# Altium schematic sheet FacePlateRev2b.SchDoc
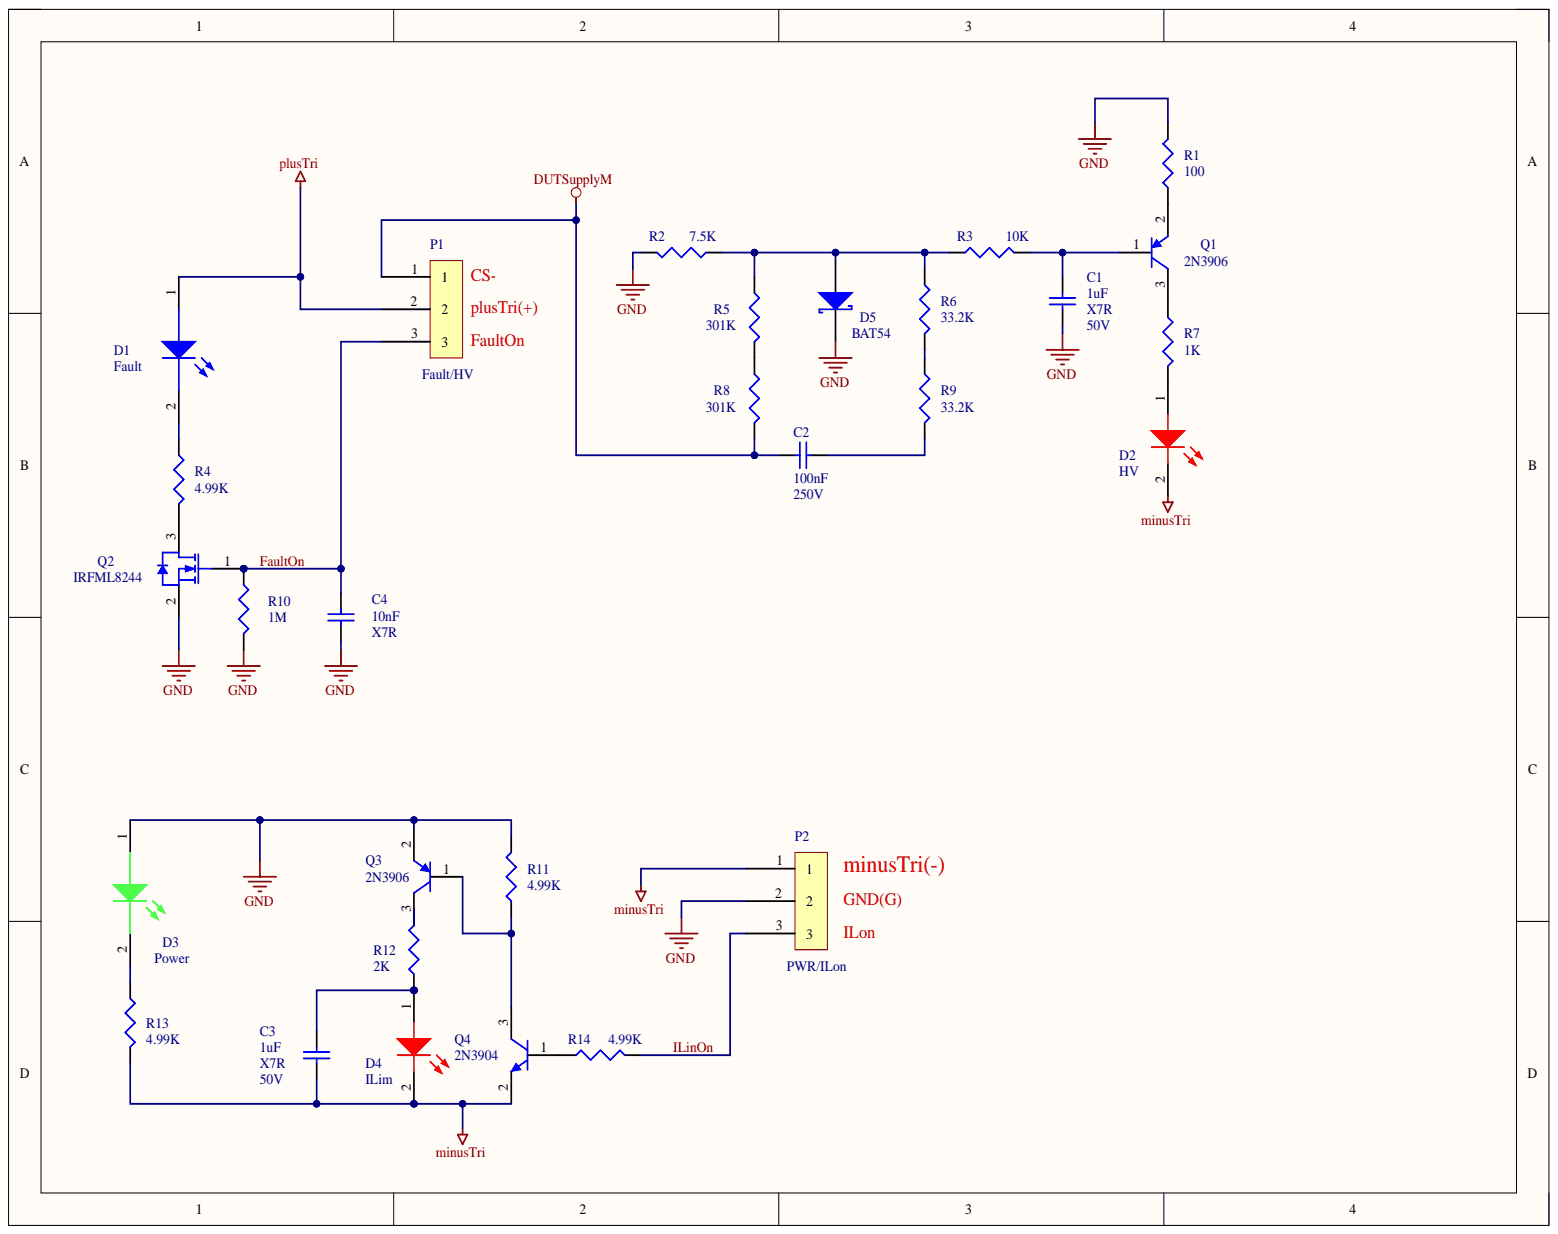
[diagram p0: FacePlateRev2b.SchDoc]

## Parts
C1  1uF  Dielectric: X7R  Voltage: 50V  [CAPC2012M]
C2  Capacitance: 100nF  Voltage Rating: 250V  [CAPC3216M]  (hidden comment: 0.1uF)
C3  1uF  Dielectric: X7R  Voltage: 50V  [CAPC2012M]
C4  10nF  Dielectric: X7R  [CAPC2012M]
D1  Fault  [LED-BOTTOM-ENTRY]
D2  HV  [LED-BOTTOM-ENTRY]
D3  Power  [LED-BOTTOM-ENTRY]
D4  ILim  [LED-BOTTOM-ENTRY]
D5  BAT54  [SOD123]
P1  Fault/HV  [NQ032]
P2  PWR/ILon  [NQ032]
Q1  2N3906  [SOT23-3M]
Q2  IRFML8244  [SOT23-3M]
Q3  2N3906  [SOT23-3M]
Q4  2N3904  [SOT23-3M]
R1  100  [RESC2012M]
R2  7.5K  [RESC2012M]
R3  10k  [RESC3216M]
R4  4.99K  [RESC3216M]
R5  value 301K  [RESC3216M]  (hidden comment: 226K)
R6  value 33.2K  [RESC3216M]  (hidden comment: 30.1K)
R7  1K  [RESC2012M]
R8  value 301K  [RESC3216M]  (hidden comment: 226K)
R9  value 33.2K  [RESC3216M]  (hidden comment: 30.1K)
R10  1M  [RESC2012M]
R11  4.99K  [RESC2012M]
R12  2K  [RESC2012M]
R13  4.99K  [RESC2012M]
R14  4.99K  [RESC3216M]

## Nets
DUTSupplyM: C2.2 P1.1 R8.2
GND: C1.2 C4.2 D3.1 D5.2 P2.2 Q2.2 Q3.2 R1.1 R2.1 R10.2 R11.2
minusTri: C3.2 D2.2 D4.2 P2.1 Q4.2 R13.2
plusTri: D1.1 P1.2
FaultOn: C4.1 P1.3 Q2.1 R10.1
ILinOn: P2.3 R14.2
NetC1_1: C1.1 Q1.1 R3.2
NetC2_1: C2.1 R9.2
NetC3_1: C3.1 D4.1 R12.1
NetD1_2: D1.2 R4.1
NetD2_1: D2.1 R7.2
NetD3_2: D3.2 R13.1
NetD5_1: D5.1 R2.2 R3.1 R5.1 R6.1
NetQ1_2: Q1.2 R1.2
NetQ1_3: Q1.3 R7.1
NetQ2_3: Q2.3 R4.2
NetQ3_1: Q3.1 Q4.3 R11.1
NetQ3_3: Q3.3 R12.2
NetQ4_1: Q4.1 R14.1
NetR5_2: R5.2 R8.1
NetR6_2: R6.2 R9.1

## Library data of the parts
C1 C3 = Cap: CAP CER 1UF 50V X7R 0805; Manufacturer: Samsung; Manufacturer Part Number: CL21B105KBFNNNE; Dielectric Material: Ceramic; Tolerance: 10%; Capacitance: 1uF; Case Code (Imperial): 0805; Case Code (Metric): 2012; Case/Package: 0805; Component Kind: Standard; Construction: Flat; Depth: 1.25mm; Height: 1.25mm; Length: 2mm; Library Name: Miscellaneous Devices.IntLib; Max Operating Temperature: 125°C; Min Operating Temperature: -55°C; Mount: Surface Mount; Number of Pins: 2; Package Quantity: 1; Packaging: Tape and Reel; Radiation Hardening: No; REACH SVHC: No SVHC; RoHS Compliant: Yes; Series: CL; Signal Integrity: Cap; Simulation: CAP; Supplier: Digi-Key; Supplier Part Number: 1276-1029-1-ND; Termination: SMD/SMT; Thickness: 0.053inch; Value: 1uF; Voltage Rating: 50V; Voltage Rating (DC): 50V; Width: 0.049inch
C2 = Cap: CAP CER 0.1UF 250V X7R 1206; Manufacturer: KEMET; Manufacturer Part Number: C1206C104KARECAUTO; Tolerance: 10%; Case Code (Imperial): 1206; Case Code (Metric): 3216; Case/Package: 1206; Component Kind: Standard; Library Name: Miscellaneous Devices.IntLib; Max Operating Temperature: 125°C; Min Operating Temperature: -55°C; Mount: Surface Mount; Packaging: Cut Tape; Ratings: AEC-Q200; Signal Integrity: Cap; Simulation: CAP; Supplier: Digi-Key; Supplier Part Number: 399-17175-1-ND; Value: 0.1uF
C4 = Cap: CAP CER 10NF 100V X7R 0805; Manufacturer: Samsung; Manufacturer Part Number: CL21B103KCANNNC; Dielectric Material: Ceramic; Dissipation Factor: 2.5%; Tolerance: 10%; Capacitance: 10nF; Case Code (Imperial): 0805; Case Code (Metric): 2012; Case/Package: 0805; Component Kind: Standard; Construction: Flat; Contact Plating: Tin; Depth: 1.25mm; Height: 0.65mm; Length: 2mm; Library Name: Miscellaneous Devices.IntLib; Material: Ceramic; Max Operating Temperature: 125°C; Min Operating Temperature: -55°C; Mount: Surface Mount; Number of Pins: 2; Package Quantity: 1; Packaging: Tape and Reel; Radiation Hardening: No; REACH SVHC: No SVHC; RoHS Compliant: Yes; Series: CL; Signal Integrity: Cap; Simulation: CAP; Supplier: Digi-Key; Supplier Part Number: 1276-1249-1-ND; Termination: SMD/SMT; Thickness: 0.03inch; Value: 10nF; Voltage: 100V; Voltage Rating: 100V; Voltage Rating (DC): 100V; Width: 0.049inch
D1 = Blue: BLUE LED 3.5X2.8MM SMD; Manufacturer: Kingbright; Manufacturer Part Number: AA3528QBS/D09; Value: LED BLUE; Category: LEDs; Component Kind: Standard; Library Name: My_LED.SchLib; Pricing 1: 1=0.55000, 10=0.35800, 100=0.23700, 1000=0.18300 (USD); RoHS: Yes; RoHS Compliant: Yes; Stock 1: 9964; Supplier: Digi-Key; Supplier Part Number: 754-AA3528QBS/D09CT-ND
D2 D4 = Red: RED LED 3.5X2.8MM SMD; Manufacturer: SunLED; Manufacturer Part Number: XZMDK45WT-9; ESR (Equivalent Series Resistance): 700mR; Lens Color: Colorless; Lens Size: 2.4mm; Lens Style: Round,Flat; Lens Transparency: Clear; Luminous Intensity: 98mcd; Value: LED RED; Category: LEDs; Color: Red; Component Kind: Standard; ComponentLink1Description: https://datasheet.ciiva.com/29561/150120rs75000-29561888.pdf?src-supplier=Digi-Key; ComponentLink1URL: https://datasheet.ciiva.com/29561/150120rs75000-29561888.pdf?src-supplier=Digi-Key; ComponentLink2Description: https://datasheet.ciiva.com/29279/759442-da-01-en-chipled-1206-w-kl-rot-150120rs75000-29279067.pdf?src-supplier=Conrad; ComponentLink2URL: https://datasheet.ciiva.com/29279/759442-da-01-en-chipled-1206-w-kl-rot-150120rs75000-29279067.pdf?src-supplier=Conrad; ComponentLink3Description: https://datasheet.ciiva.com/48430/150120rs75000-eng-tds-48430054.pdf?src-supplier=Distrelec; ComponentLink3URL: https://datasheet.ciiva.com/48430/150120rs75000-eng-tds-48430054.pdf?src-supplier=Distrelec; ComponentLink4Description: https://datasheet.ciiva.com/22656/1911465-22656982.pdf?src-supplier=Element14; ComponentLink4URL: https://datasheet.ciiva.com/22656/1911465-22656982.pdf?src-supplier=Element14; ComponentLink5Description: https://datasheet.ciiva.com/22657/156120rs75300-22657072.pdf?src-supplier=Element14; ComponentLink5URL: https://datasheet.ciiva.com/22657/156120rs75300-22657072.pdf?src-supplier=Element14; ComponentLink6Description: https://datasheet.ciiva.com/29279/759450-da-01-en-smd-chipled-rev-rot-156120rs75300-29279064.pdf?src-supplier=Conrad; ComponentLink6URL: https://datasheet.ciiva.com/29279/759450-da-01-en-smd-chipled-rev-rot-156120rs75300-29279064.pdf?src-supplier=Conrad; ComponentLink7Description: https://datasheet.ciiva.com/48430/156120rs75300-eng-tds-48430214.pdf?src-supplier=Distrelec; ComponentLink7URL: https://datasheet.ciiva.com/48430/156120rs75300-eng-tds-48430214.pdf?src-supplier=Distrelec; ComponentLink8Description: http://www.sunledusa.com/products/spec/XZMDK45WT-9.pdf?src-supplier=Digi-Key; ComponentLink8URL: http://www.sunledusa.com/products/spec/XZMDK45WT-9.pdf?src-supplier=Digi-Key; Library Name: My_LED.SchLib; Max Operating Temperature: 105°C; Min Operating Temperature: -55°C; Mount: Surface Mount; Packaging: Tape and Reel; Pricing 1: 1=0.57000, 10=0.37200, 100=0.24610, 1000=0.19004 (USD); Ratings: AEC-Q200; RoHS: Yes; RoHS Compliant: No; Stock 1: 137856; Supplier: Digi-Key; Supplier Part Number: 1497-1331-1-ND
D3 = Green: GREEN LED 3.5X2.8MM SMD; Manufacturer: SunLED; Manufacturer Part Number: XZVG45WT-9; Dominant Wavelength: 570nm; Forward Voltage: 2.1V; Lens Color: Colorless; Lens Size: 2.4mm; Lens Style: Round,Flat; Lens Transparency: Clear; Luminous Intensity: 98mcd; Test Current: 20mA; Value: LED GREEN; Viewing Angle: 120°; Case/Package: SMD/SMT; Category: LEDs; Color: Green; Component Kind: Standard; ComponentLink1Description: https://datasheet.ciiva.com/23843/156120vs75300-23843298.pdf?src-supplier=Newark; ComponentLink1URL: https://datasheet.ciiva.com/23843/156120vs75300-23843298.pdf?src-supplier=Newark; ComponentLink2Description: https://datasheet.ciiva.com/29279/759452-da-01-en-smd-chipled-rev-h-gruen-156120vs75300-29279069.pdf?src-supplier=Conrad; ComponentLink2URL: https://datasheet.ciiva.com/29279/759452-da-01-en-smd-chipled-rev-h-gruen-156120vs75300-29279069.pdf?src-supplier=Conrad; ComponentLink3Description: https://datasheet.ciiva.com/22657/1911474-22657077.pdf?src-supplier=Element14; ComponentLink3URL: https://datasheet.ciiva.com/22657/1911474-22657077.pdf?src-supplier=Element14; ComponentLink4Description: http://www.sunledusa.com/products/spec/XZMDK55W-3.pdf?src-supplier=Digi-Key; ComponentLink4URL: http://www.sunledusa.com/products/spec/XZMDK55W-3.pdf?src-supplier=Digi-Key; ComponentLink5Description: http://www.sunledusa.com/products/spec/XZVG45WT-9.pdf?src-supplier=Digi-Key; ComponentLink5URL: http://www.sunledusa.com/products/spec/XZVG45WT-9.pdf?src-supplier=Digi-Key; Height: 2.1mm; Length: 3.2mm; Library Name: My_LED.SchLib; Mount: Surface Mount; Packaging: Tape and Reel; Pricing 1: 1=0.52000, 10=0.34400, 100=0.22790, 1000=0.17596 (USD); RoHS: No; RoHS Compliant: No; Stock 1: 176753; Supplier: Digi-Key; Supplier Part Number: 1497-1336-1-ND; Width: 2.8mm
D5 = Diode-Schottkey-12: SBAT54T1G Series 30 200 mA Surface Mount Schottky Barrier Diode - SOD-123; Manufacturer: ON Semiconductor; Average Rectified Current: 200mA; Element Configuration: Single; Forward Voltage: 520mV; Max Forward Surge Current (Ifsm): 600mA; Max Power Dissipation: 400mW; Max Repetitive Reverse Voltage (Vrrm): 30V; Max Reverse Current: 500nA; Reverse Recovery Time: 5ns; Category: Schottky Diodes; Component Kind: Standard; ComponentLink1Description: http://www.farnell.com/datasheets/1840528.pdf?src-supplier=Farnell; ComponentLink1URL: http://www.farnell.com/datasheets/1840528.pdf?src-supplier=Farnell; ComponentLink2Description: http://www.mouser.com/ds/2/308/BAT54T1-D-108887.pdf?src-supplier=Mouser; ComponentLink2URL: http://www.mouser.com/ds/2/308/BAT54T1-D-108887.pdf?src-supplier=Mouser; ComponentLink3Description: http://www.onsemi.com/pub/Collateral/BAT54T1-D.PDF?src-supplier=Digi-Key; ComponentLink3URL: http://www.onsemi.com/pub/Collateral/BAT54T1-D.PDF?src-supplier=Digi-Key; ComponentLink4Description: http://www.onsemi.com/pub/Collateral/BAT54T1-D.PDF?src-supplier=Avnet; ComponentLink4URL: http://www.onsemi.com/pub/Collateral/BAT54T1-D.PDF?src-supplier=Avnet; Forward Current: 200mA; Lead Free: Lead Free; Library Name: MY_Diodes.SchLib; Manufacturer Part Number: SBAT54T1G; Max Operating Temperature: 125°C; Max Reverse Voltage (DC): 30V; Min Operating Temperature: -55°C; Mount: Surface Mount; Package Quantity: 3000; Packaging: Tape and Reel; Pricing 1: 1=0.51000, 10=0.41100, 100=0.27980, 500=0.20988, 1000=0.15741 (USD); Reverse Voltage (DC): 30V; RoHS: Yes; RoHS Compliant: Yes; Supplier: Digi-Key; Supplier Part Number: SBAT54T1GOSCT-ND; Value: BAT54
P1 P2 = 3-Pin: NQ-4.0-3P 4MM 3PIN SMC; Manufacturer: Amphenol; Manufacturer Part Number: NQ0321100000G; Current: 9A; Number of Levels: 1; Number of Positions Per Level: 3; Value: NQ032; Voltage: 300V; Wire Gauge (Max): 24AWG; Wire Gauge (Min): 18AWG; Color: Natural; Component Kind: Standard; ComponentLink1Description: http://portal.fciconnect.com/Comergent//fci/drawing/nqxx21x000j0g.pdf?src-supplier=Digi-Key; ComponentLink1URL: http://portal.fciconnect.com/Comergent//fci/drawing/nqxx21x000j0g.pdf?src-supplier=Digi-Key; Housing Material: Polymer; Library Name: MY_CONNECTORS.SchLib; Max Operating Temperature: 130°C; Min Operating Temperature: -40°C; Mount: Surface Mount; Packaging: Tape and Reel; RoHS: Yes; RoHS Compliant: Yes; Supplier: Digi-Key; Supplier Part Number: 609-5214-1-ND; Termination: Screwless
Q1 Q3 = 2N3906: TRANS PNP 40V 0.2A SOT23; Manufacturer: ON Semiconductor; Manufacturer Part Number: MMBT3906LT1G; Current - Collector (Ic) (Max): 200mA; DC Current Gain (hFE) (Min) @ Ic, Vce: 100 @ 10mA, 1V; Frequency - Transition: 250MHz; Power - Max: 300mW; Vce Saturation (Max) @ Ib, Ic: 400mV @ 5mA, 50mA; Category: Discrete Semiconductor Products; Component Kind: Standard; Library Name: Miscellaneous Devices.IntLib; Mounting Type: Surface Mount; Package / Case: TO-236-3, SC-59, SOT-23-3; Packaging: Cut Tape (CT); RoHS: RoHS Compliant; Signal Integrity: 2N3906; Simulation: 2N3906; Supplier: Digi-Key; Supplier Device Package: SOT-23-3 (TO-236); Supplier Part Number: MMBT3906LT1GOSCT-ND; Transistor Type: PNP; Value: 2N3906; Voltage - Collector Emitter Breakdown (Max): 40V
Q2 = 2N7000: TRANS N-CH 25V 1.25 W SOT-23; Manufacturer: International Rectifier; Manufacturer Part Number: IRFML8244TRPBF; Continuous Drain Current (ID): 5.8A; Drain to Source Resistance: 41mR; Element Configuration: Single; Fall Time: 2.9ns; Gate to Source Voltage (Vgs): 20V; Input Capacitance: 430pF; Max Power Dissipation: 1.25W; Nominal Vgs: 1.7V; Number of Elements: 1; Rds On Max: 24mR; Recovery Time: 17ns; Rise Time: 2.1ns; Turn-Off Delay Time: 9ns; Turn-On Delay Time: 2.7ns; Case/Package: SOT-23; Component Kind: Standard; Drain to Source Breakdown Voltage: 25V; Drain to Source Voltage (Vdss): 25V; Drain-source On Resistance-Max: 41MR; Height: 1.02mm; Lead Free: Lead Free; Length: 3.04mm; Library Name: Philips Discrete MOSFET - Low Power.IntLib; Max Operating Temperature: 150°C; Min Operating Temperature: -55°C; Mount: Surface Mount; Number of Pins: 3; On-State Resistance: 41MR; Package Quantity: 1; Packaging: Tape and Reel; Power Dissipation: 1.25W; Radiation Hardening: No; REACH SVHC: No SVHC; Series: HEXFET®; Simulation: 2N7000; Supplier: Digi-Key; Supplier Part Number: IRFML8244TRPBFCT-ND; Threshold Voltage: 1.7V; Value: IRFML8244; Width: 1.4mm
Q4 = 2N3904: TRANS NPN 40V 0.2A SOT23; Manufacturer: ON Semiconductor; Manufacturer Part Number: MMBT3904LT1G; Current - Collector (Ic) (Max): 200mA; DC Current Gain (hFE) (Min) @ Ic, Vce: 100 @ 10mA, 1V; Frequency - Transition: 300MHz; Power - Max: 300mW; Vce Saturation (Max) @ Ib, Ic: 300mV @ 5mA, 50mA; Category: Discrete Semiconductor Products; Code_JEDEC: TO-92A; Component Kind: Standard; ComponentLink1Description: Manufacturer Link; ComponentLink1URL: http://www.fairchildsemi.com/; ComponentLink2Description: Datasheet; ComponentLink2URL: http://www.fairchildsemi.com/ds/2N%2F2N3904.pdf; LatestRevisionDate: 15-Jan-2003; LatestRevisionNote: PCB Footprint 'BCY-W3/D4.7' replaced; Library Name: My_Miscellaneous Devices.SchLib; Mounting Type: Surface Mount; Operating Temperature: -55°C ~ 150°C (TJ); Package / Case: TO-236-3, SC-59, SOT-23-3; PackageVersion: Sep-1998; Packaging: Cut Tape (CT); Part Status: Active; RoHS: RoHS Compliant; Signal Integrity: 2N3904; Simulation: 2N3904; Supplier: Digi-Key; Supplier Device Package: SOT-23-3 (TO-236); Supplier Part Number: MMBT3904LT1GOSCT-ND; Transistor Type: NPN; Value: 2N3904; Voltage - Collector Emitter Breakdown (Max): 40V
R1 = Res3: RES 100 OHM 1% 1/4W 0805; Manufacturer: KOA Speer; Manufacturer Part Number: RK73H2ATTD1000F; Power Rating: 125mW; Resistance: 100R; Temperature Coefficient: 100ppm/°C; Voltage Rating: 150V; Case Code (Imperial): 0805; Case Code (Metric): 2012; Case/Package: 0805; Component Kind: Standard; Construction: Rectangular; Depth: 1.25mm; Height: 0.5mm; Lead Free: Lead Free; Length: 2mm; Library Name: Miscellaneous Devices.IntLib; Max Operating Temperature: 155°C; Military Standard: Not; Min Operating Temperature: -55°C; Mount: Surface Mount; Number of Pins: 2; Package Quantity: 5000; Packaging: Tape and Reel; REACH SVHC: Unknown; Resistance Tolerance: 1%; Resistor Type: Thick Film; RoHS Compliant: Yes; Series: RK73H; Signal Integrity: Res; Simulation: RESISTOR; Supplier: Digi-Key; Supplier Part Number: 2019-RK73H2ATTD1000FCT-ND; Technology: THICK FILM; Termination: SMD/SMT; Tolerance: 1%; Value: 100; Voltage Rating (DC): 150V; Width: 1.25mm
R2 = Res3: RES 7.5K OHM 1% 1/4W 0805; Manufacturer: KOA Speer; Manufacturer Part Number: RK73H2ATTD7501F; Power Rating: 125mW; Resistance: 7.5kR; Temperature Coefficient: 100ppm/°C; Voltage Rating: 150V; Case Code (Imperial): 0805; Case Code (Metric): 2012; Case/Package: 0805; Component Kind: Standard; Construction: Rectangular; Depth: 1.25mm; Height: 0.5mm; Lead Free: Lead Free; Length: 2mm; Library Name: Miscellaneous Devices.IntLib; Max Operating Temperature: 155°C; Military Standard: Not; Min Operating Temperature: -55°C; Mount: Surface Mount; Number of Pins: 2; Package Quantity: 5000; Packaging: Tape and Reel; Resistance Tolerance: 1%; Resistor Type: Thick Film; RoHS Compliant: Yes; Series: RK73H; Signal Integrity: Res; Simulation: RESISTOR; Supplier: Digi-Key; Supplier Part Number: 2019-RK73H2ATTD7501FCT-ND; Technology: THICK FILM; Termination: SMD/SMT; Tolerance: 1%; Value: 7.5K; Voltage Rating (DC): 150V; Width: 1.25mm
R3 = Res3: RES 10K OHM 1% 1/4W 0805; Manufacturer: KOA Speer; Manufacturer Part Number: RK73H2ATTD1002F; Max Power Dissipation: 250mW; Power Rating: 125mW; Resistance: 10kR; Temperature Coefficient: 100ppm/°C; Voltage Rating: 150V; Case Code (Imperial): 0805; Case Code (Metric): 2012; Case/Package: 0805; Component Kind: Standard; Composition: Thick Film; Construction: Rectangular; Contact Plating: Matte,Tin; Depth: 1.25mm; Features: Anti-Sulfur,Moisture Resistant; Height: 0.5mm; Lead Free: Lead Free; Length: 2mm; Library Name: Miscellaneous Devices.IntLib; Max Operating Temperature: 155°C; Military Standard: Not; Min Operating Temperature: -55°C; Mount: Surface Mount; Number of Pins: 2; Number of Terminations: 2; Package Quantity: 5000; Packaging: Tape and Reel; Radiation Hardening: No; REACH SVHC: Unknown; Resistance Tolerance: 1%; Resistor Type: Thick Film; RoHS Compliant: Yes; Series: RK73H; Signal Integrity: Res; Simulation: RESISTOR; Supplier: Digi-Key; Supplier Part Number: 2019-RK73H2ATTD1002FCT-ND; Termination: SMD/SMT; Tolerance: 1%; Value: 10K; Voltage Rating (DC): 150V; Width: 1.25mm
R4 R14 = Res3: RES 4.99K OHM 1% 1/4W 1206; Manufacturer: Stackpole Electronics; Manufacturer Part Number: RMCF1206FT4K99; Max Power Dissipation: 250mW; Resistance: 4.99kR; Temperature Coefficient: 100ppm/°C; Case Code (Imperial): 1206; Case Code (Metric): 3216; Case/Package: 1206; Component Kind: Standard; Composition: Thick Film; Contact Plating: Tin,Matte; Depth: 1.6mm; Features: Automotive AEC-Q200; Height: 0.028inch; Lead Free: Lead Free; Length: 0.126inch; Library Name: Miscellaneous Devices.IntLib; Max Operating Temperature: 155°C; Military Standard: Not; Min Operating Temperature: -55°C; Mount: Surface Mount; Number of Terminations: 2; Package Quantity: 1; Packaging: Tape and Reel; Power Rating: 250mW; Radiation Hardening: No; Signal Integrity: Res; Simulation: RESISTOR; Supplier: Digi-Key; Supplier Part Number: RMCF1206FT4K99CT-ND; Tolerance: 1%; Value: 4.99K; Width: 0.063inch
R5 = Res3: Res Thick Film 1206 301K Ohm 1% 0.25W ±100ppm/°C Molded SMD Paper T/R; Manufacturer: Stackpole Electronics; Manufacturer Part Number: RMCF1206FT301K; Max Power Dissipation: 250mW; Resistance: 301kR; Case Code (Imperial): 1206; Case Code (Metric): 3216; Case/Package: 1206; Category: Chip SMD Resistors; Component Kind: Standard; ComponentLink1Description: http://components.arrow.com/part/dataview/40949670S6804816N1174?src-supplier=Arrow+Electronics; ComponentLink1URL: http://components.arrow.com/part/dataview/40949670S6804816N1174?src-supplier=Arrow+Electronics; ComponentLink2Description: https://www.seielect.com/catalog/SEI-RMCF_RMCP.pdf?src-supplier=Avnet; ComponentLink2URL: https://www.seielect.com/catalog/SEI-RMCF_RMCP.pdf?src-supplier=Avnet; ComponentLink3Description: http://www.seielect.com/Catalog/SEI-RMCF_RMCP.pdf?src-supplier=Digi-Key; ComponentLink3URL: http://www.seielect.com/Catalog/SEI-RMCF_RMCP.pdf?src-supplier=Digi-Key; ComponentLink4Description: https://www.seielect.com/Catalog/SEI-RMCF_RMCP.pdf?src-supplier=Digi-Key; ComponentLink4URL: https://www.seielect.com/Catalog/SEI-RMCF_RMCP.pdf?src-supplier=Digi-Key; ComponentLink5Description: https://www.seielect.com/catalog/SEI-Packaging.pdf?src-supplier=Digi-Key; ComponentLink5URL: https://www.seielect.com/catalog/SEI-Packaging.pdf?src-supplier=Digi-Key; ComponentLink6Description: http://components.arrow.com/part/dataview/40949655S7370296N1174?src-supplier=Arrow+Electronics; ComponentLink6URL: http://components.arrow.com/part/dataview/40949655S7370296N1174?src-supplier=Arrow+Electronics; Contact Plating: Tin,Matte; Features: Automotive AEC-Q200; Height: 0.028inch; Length: 0.126inch; Library Name: Miscellaneous Devices.IntLib; Max Operating Temperature: 155°C; Min Operating Temperature: -55°C; Mount: Surface Mount; Number of Terminations: 2; Packaging: Tape and Reel; Pricing 1: 1=0.10000, 10=0.03600, 100=0.01460, 1000=0.00655, 2500=0.00569 (USD); Radiation Hardening: No; Resistor Type: Thick Film; RoHS: Yes; RoHS Compliant: Yes; Series: RMCF; Signal Integrity: Res; Simulation: RESISTOR; Supplier: Digi-Key; Supplier Part Number: RMCF1206FT301KCT-ND; Temperature Coefficient: 100ppm/°C; Tolerance: 1%; Width: 0.063inch
R6 R9 = Res3: RES 33.2K OHM 1% 1/4W 1206; Manufacturer: Stackpole Electronics; Manufacturer Part Number: RMCF1206FT33K2; Max Power Dissipation: 250mW; Resistance: 33.2kR; Temperature Coefficient: 100ppm/°C; Case Code (Imperial): 1206; Case Code (Metric): 3216; Case/Package: 1206; Component Kind: Standard; Composition: Thick Film; Contact Plating: Matte,Tin; Depth: 1.6mm; Features: Automotive AEC-Q200; Height: 0.028inch; Lead Free: Lead Free; Length: 0.126inch; Library Name: Miscellaneous Devices.IntLib; Max Operating Temperature: 155°C; Military Standard: Not; Min Operating Temperature: -55°C; Mount: Surface Mount; Number of Terminations: 2; Packaging: Tape and Reel; Power Rating: 250mW; Radiation Hardening: No; Signal Integrity: Res; Simulation: RESISTOR; Supplier: Digi-Key; Supplier Part Number: RMCF1206FT33K2CT-ND; Tolerance: 1%; Width: 0.063inch
R7 = Res3: RES 1K OHM 1% 1/4W 0805; Manufacturer: KOA Speer; Manufacturer Part Number: RK73H2ATTD1001F; Max Power Dissipation: 250mW; Power Rating: 125mW; Resistance: 1kR; Temperature Coefficient: 100ppm/°C; Voltage Rating: 150V; Case Code (Imperial): 0805; Case Code (Metric): 2012; Case/Package: 0805; Component Kind: Standard; Composition: Thick Film; Contact Plating: Matte,Tin; Depth: 1.6mm; Features: Automotive AEC-Q200; Height: 0.5mm; Lead Free: Lead Free; Length: 2mm; Library Name: Miscellaneous Devices.IntLib; Max Operating Temperature: 155°C; Military Standard: Not; Min Operating Temperature: -55°C; Mount: Surface Mount; Number of Terminations: 2; Package Quantity: 5000; Packaging: Tape and Reel; Radiation Hardening: No; RoHS Compliant: Yes; Series: RK73H; Signal Integrity: Res; Simulation: RESISTOR; Supplier: Digi-Key; Supplier Part Number: 2019-RK73H2ATTD1001FCT-ND; Termination: SMD/SMT; Tolerance: 1%; Value: 1K; Voltage Rating (DC): 150V; Width: 1.25mm
R8 = Res3: Res Thick Film 1206 301K Ohm 1% 0.25W ±100ppm/°C Molded SMD Paper T/R; Manufacturer Part Number: RMCF1206FT301K; Max Power Dissipation: 250mW; Resistance: 301kR; Case Code (Imperial): 1206; Case Code (Metric): 3216; Case/Package: 1206; Category: Chip SMD Resistors; Component Kind: Standard; ComponentLink1Description: http://components.arrow.com/part/dataview/40949670S6804816N1174?src-supplier=Arrow+Electronics; ComponentLink1URL: http://components.arrow.com/part/dataview/40949670S6804816N1174?src-supplier=Arrow+Electronics; ComponentLink2Description: https://www.seielect.com/catalog/SEI-RMCF_RMCP.pdf?src-supplier=Avnet; ComponentLink2URL: https://www.seielect.com/catalog/SEI-RMCF_RMCP.pdf?src-supplier=Avnet; ComponentLink3Description: http://www.seielect.com/Catalog/SEI-RMCF_RMCP.pdf?src-supplier=Digi-Key; ComponentLink3URL: http://www.seielect.com/Catalog/SEI-RMCF_RMCP.pdf?src-supplier=Digi-Key; ComponentLink4Description: https://www.seielect.com/Catalog/SEI-RMCF_RMCP.pdf?src-supplier=Digi-Key; ComponentLink4URL: https://www.seielect.com/Catalog/SEI-RMCF_RMCP.pdf?src-supplier=Digi-Key; ComponentLink5Description: https://www.seielect.com/catalog/SEI-Packaging.pdf?src-supplier=Digi-Key; ComponentLink5URL: https://www.seielect.com/catalog/SEI-Packaging.pdf?src-supplier=Digi-Key; ComponentLink6Description: http://components.arrow.com/part/dataview/40949655S7370296N1174?src-supplier=Arrow+Electronics; ComponentLink6URL: http://components.arrow.com/part/dataview/40949655S7370296N1174?src-supplier=Arrow+Electronics; Contact Plating: Tin,Matte; Features: Automotive AEC-Q200; Height: 0.028inch; Length: 0.126inch; Library Name: Miscellaneous Devices.IntLib; Max Operating Temperature: 155°C; Min Operating Temperature: -55°C; Mount: Surface Mount; Number of Terminations: 2; Packaging: Tape and Reel; Pricing 1: 1=0.10000, 10=0.03600, 100=0.01460, 1000=0.00655, 2500=0.00569 (USD); Radiation Hardening: No; Resistor Type: Thick Film; RoHS: Yes; RoHS Compliant: Yes; Series: RMCF; Signal Integrity: Res; Simulation: RESISTOR; Supplier: Digi-Key; Supplier Part Number: RMCF1206FT301KCT-ND; Temperature Coefficient: 100ppm/°C; Tolerance: 1%; Width: 0.063inch
R10 = Res3: RES 1M OHM 1% 1/8W 0805; Manufacturer: KOA Speer; Manufacturer Part Number: RK73H2ATTD1004F; Max Power Dissipation: 250mW; Power Rating: 125mW; Resistance: 1MR; Temperature Coefficient: 100ppm/°C; Voltage Rating: 150V; Case Code (Imperial): 0805; Case Code (Metric): 2012; Case/Package: 0805; Component Kind: Standard; Composition: Thick Film; Construction: Rectangular; Contact Plating: Matte,Tin; Depth: 1.25mm; Features: Automotive AEC-Q200; Height: 0.5mm; Lead Free: Lead Free; Length: 2mm; Library Name: Miscellaneous Devices.IntLib; Max Operating Temperature: 155°C; Military Standard: Not; Min Operating Temperature: -55°C; Mount: Surface Mount; Number of Pins: 2; Number of Terminations: 2; Package Quantity: 5000; Packaging: Tape and Reel; Radiation Hardening: No; REACH SVHC: Unknown; Resistance Tolerance: 1%; Resistor Type: Thick Film; RoHS Compliant: Yes; Series: RK73H; Signal Integrity: Res; Simulation: RESISTOR; Supplier: Digi-Key; Supplier Part Number: 2019-RK73H2ATTD1004FCT-ND; Technology: THICK FILM; Termination: SMD/SMT; Tolerance: 1%; Value: 1M; Voltage Rating (DC): 150V; Width: 1.25mm
R11 R13 = Res3: RES 4.99K OHM 1% 1/4W 0805; Manufacturer: KOA Speer; Manufacturer Part Number: RK73H2ATTD4991F; Max Power Dissipation: 250mW; Power Rating: 125mW; Resistance: 4.99kR; Temperature Coefficient: 100ppm/°C; Voltage Rating: 150V; Case Code (Imperial): 0805; Case Code (Metric): 2012; Case/Package: 0805; Component Kind: Standard; Composition: Thick Film; Construction: Rectangular; Contact Plating: Matte,Tin; Depth: 1.25mm; Features: Automotive AEC-Q200; Height: 0.5mm; Lead Free: Lead Free; Length: 2mm; Library Name: Miscellaneous Devices.IntLib; Max Operating Temperature: 155°C; Military Standard: Not; Min Operating Temperature: -55°C; Mount: Surface Mount; Number of Pins: 2; Number of Terminations: 2; Package Quantity: 5000; Packaging: Tape and Reel; Radiation Hardening: No; REACH SVHC: Unknown; Resistance Tolerance: 1%; Resistor Type: Thick Film; RoHS Compliant: Yes; Series: RK73H; Signal Integrity: Res; Simulation: RESISTOR; Supplier: Digi-Key; Supplier Part Number: 2019-RK73H2ATTD4991FCT-ND; Technology: THICK FILM; Termination: SMD/SMT; Tolerance: 1%; Value: 4.99K; Voltage Rating (DC): 150V; Width: 1.25mm
R12 = Res3: RES 2K OHM 1% 1/4W 0805; Manufacturer: KOA Speer; Manufacturer Part Number: RK73H2ATTD2001F; Max Power Dissipation: 250mW; Power Rating: 125mW; Resistance: 2kR; Temperature Coefficient: 100ppm/°C; Voltage Rating: 150V; Case Code (Imperial): 0805; Case Code (Metric): 2012; Case/Package: 0805; Component Kind: Standard; Composition: Thick Film; Construction: Rectangular; Contact Plating: Matte,Tin; Depth: 1.25mm; Features: Automotive AEC-Q200; Height: 0.5mm; Lead Free: Lead Free; Length: 2mm; Library Name: Miscellaneous Devices.IntLib; Max Operating Temperature: 155°C; Military Standard: Not; Min Operating Temperature: -55°C; Mount: Surface Mount; Number of Pins: 2; Number of Terminations: 2; Package Quantity: 5000; Packaging: Tape and Reel; Radiation Hardening: No; REACH SVHC: Unknown; Resistance Tolerance: 1%; Resistor Type: Thick Film; RoHS Compliant: Yes; Series: RK73H; Signal Integrity: Res; Simulation: RESISTOR; Supplier: Digi-Key; Supplier Part Number: 2019-RK73H2ATTD2001FCT-ND; Technology: THICK FILM; Termination: SMD/SMT; Tolerance: 1%; Value: 2K; Voltage Rating (DC): 150V; Width: 1.25mm
